# Altium schematic sheet CAN Bus Network.SchDoc
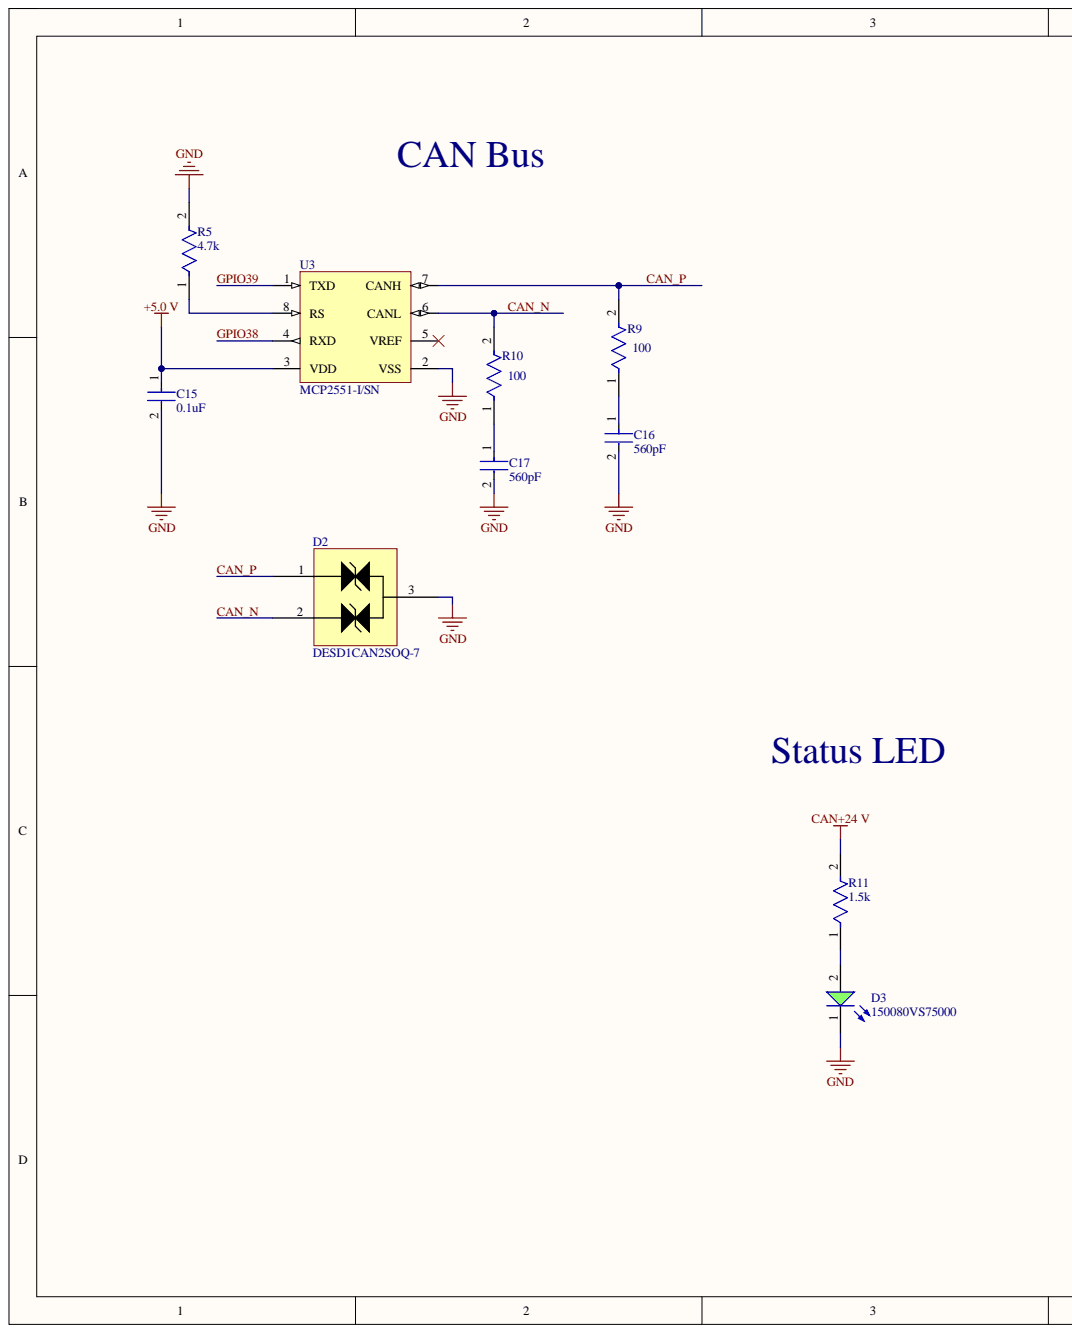
[diagram p0: CAN Bus Network.SchDoc, left]
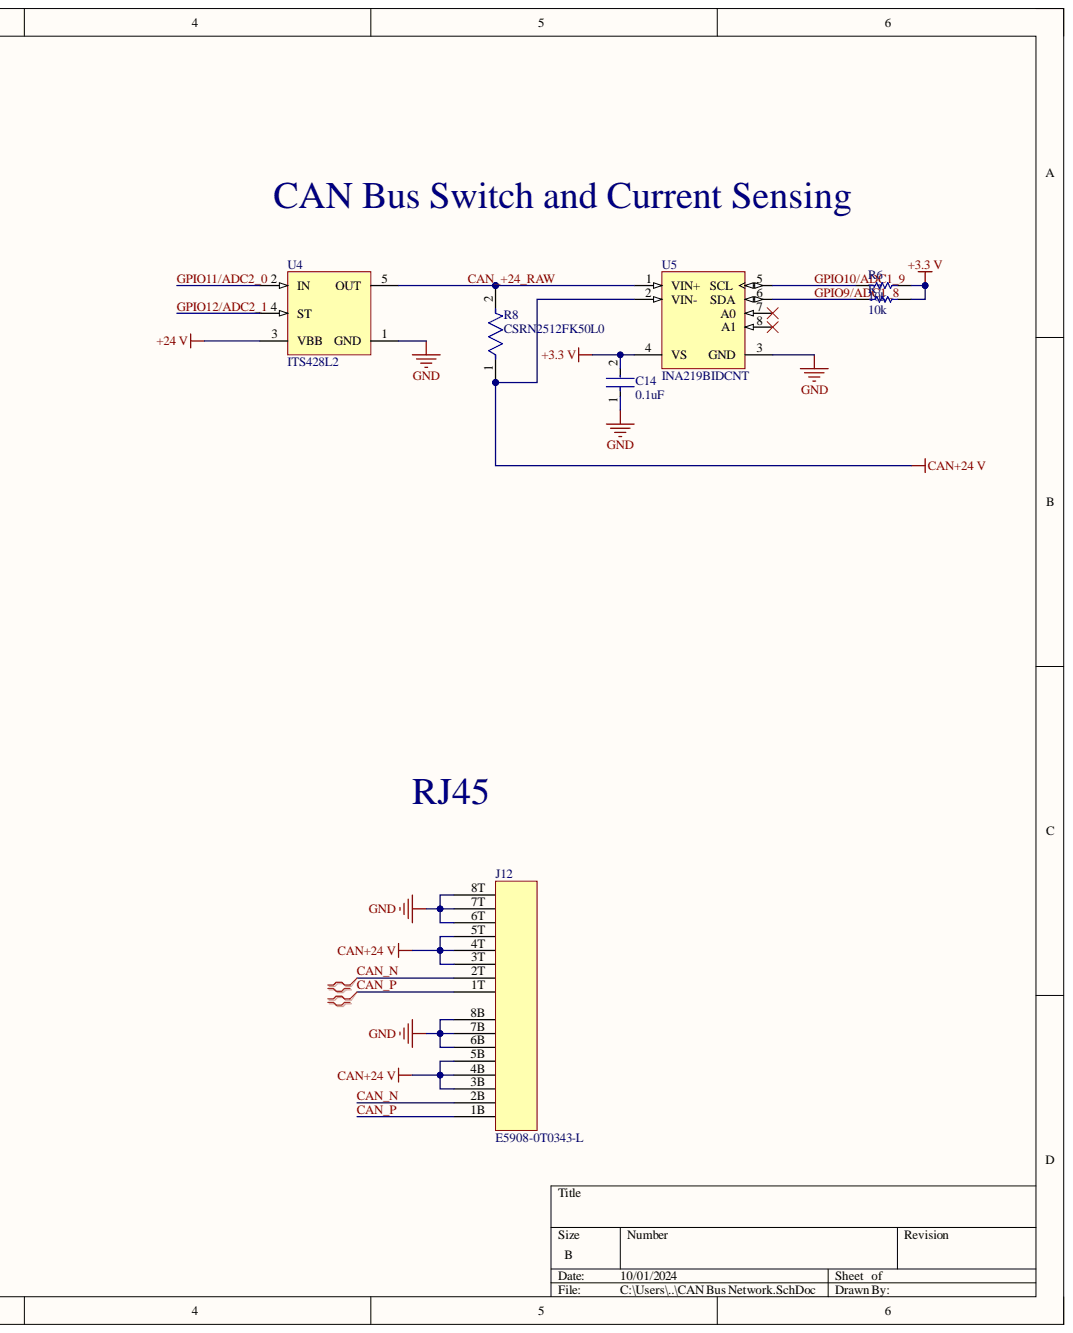
[diagram p1: CAN Bus Network.SchDoc, right]

## Parts
C14  0.1uF  [FP-CC0603-DA-IPC_A]
C15  0.1uF  [FP-CC0603-DA-IPC_A]
C16  560pF  [FP-CC1206-1_25-IPC_A]
C17  560pF  [FP-CC1206-1_25-IPC_A]
D2  DESD1CAN2SOQ-7  [SOT95P245X110-3N]
D3  150080VS75000  [WL-SMCW_0805_150080xx75000]
J12  E5908-0T0343-L  [E5908-0T0343-L-Footprint-1]
R5  4.7k  [FP-RT0805-IPC_A]
R6  10k  [RESC2013X60X35ML10T20]
R7  10k  [RESC2013X60X35ML10T20]
R8  CSRN2512FK50L0  [FP-CSRN2512-IPC_B]
R9  100  [FP-RT0805-0_6-IPC_A]
R10  100  [FP-RT0805-0_6-IPC_A]
R11  1.5k  [FP-RT0805-IPC_A]
U3  MCP2551-I/SN  [MCHP-SN8_V]
U4  ITS428L2  [INF-PG-TO252-5-11_M]
U5  INA219BIDCNT  [DCN0008A_M]

## Nets
+3.3 V: C14.2 R6.1 R7.1 U5.4(VS)
+5.0 V: C15.1 U3.3(VDD)
+24 V: U4.3(VBB)
CAN+24 V: J12.3B J12.3T J12.4B J12.4T J12.5B J12.5T R8.1 R11.2 U5.2(VIN-)
GND: C14.1 C15.2 C16.2 C17.2 D2.3 D3.1 J12.6B J12.6T J12.7B J12.7T J12.8B J12.8T R5.2 U3.2(VSS) U4.1(GND) U5.3(GND)
CAN_+24_RAW: R8.2 U4.5(OUT) U5.1(VIN+)
CAN_N: D2.2 J12.2B J12.2T R10.2 U3.6(CANL)
CAN_P: D2.1 J12.1B J12.1T R9.2 U3.7(CANH)
GPIO9/ADC1_8: R7.2 U5.6(SDA)
GPIO10/ADC1_9: R6.2 U5.5(SCL)
GPIO11/ADC2_0: U4.2(IN)
GPIO12/ADC2_1: U4.4(ST)
GPIO38: U3.4(RXD)
GPIO39: U3.1(TXD)
NetC16_1: C16.1 R9.1
NetC17_1: C17.1 R10.1
NetD3_2: D3.2 R11.1
NetR5_1: R5.1 U3.8(RS)

Not connected: U3 5(VREF); U5 7(A0) 8(A1)

## Library data of the parts
C14 C15 = CMP-00002-1: Ceramic Capacitor, Multilayer, Ceramic, 50V, 10% +Tol, 10% -Tol, X7R, 15% TC, 0.1uF, Surface Mount, 0603; Multilayer: Yes; Value: 100nF; Capacitor Type: Ceramic; Case/Package: 0603; Component Kind: Standard; Dielectric Material: Ceramic; Height: 0.8mm; JESD-609 Code: e3; Length: 1.6mm; Library Name: C0514760 C0514760; Max Operating Temperature: 125°C; Min Operating Temperature: -55°C; Mounting Technology: Surface Mount; Package Shape: Rectangular; Package Style: SMTMeter; Packaging: Tape and Reel; Pins: 2; Rated DC Voltage (URdc): 50V; Reach SVHC Compliant: Yes; RoHS Compliant: Yes; Temperature Characteristics Code: X7R; Terminal Finish: Nickel; Termination: Wraparound; Tolerance  (fill in as +-): 10%; Tolerance (fill in as +-): 10%; Width: 0.8mm
C16 C17 = CMP-00008-1: Ceramic Capacitor, Multilayer, Ceramic, 50V, 10% +Tol, 10% -Tol, X7R, 15% TC, 0.00056uF, Surface Mount, 1206; Multilayer: Yes; Capacitor Type: Ceramic; Case/Package: 1206; Component Kind: Standard; Dielectric Material: Ceramic; JESD-609 Code: e3; Library Name: C0514760 C0514760; Max Operating Temperature: 125°C; Min Operating Temperature: -55°C; Mounting Technology: Surface Mount; Package Shape: Rectangular; Packaging: Tape and Reel; Pins: 2; Rated DC Voltage (URdc): 50V; Reach SVHC Compliant: Yes; RoHS Compliant: Yes; Temperature Characteristics Code: X7R; Terminal Finish: Tin; Termination: Wraparound; Tolerance  (fill in as +-): 10%; Tolerance (fill in as +-): 10%; Value: 560pF
D2 = CMP-00024-2: Trans Voltage Suppressor Diode, 200W, 24V V(RWM), Bidirectional, 2 Element, Silicon; Additional Feature: HIGH RELIABILITY; Max Breakdown Voltage: 30.3V; Max Power Dissipation: 300mW; Min Breakdown Voltage: 25.4V; Number of Elements: 2; Package Body Material: Plastic; Reference Standard: AEC-Q101; Time @ Peak Reflow Temperature-Max (s): 30s; Component Kind: Standard; Diode Element Material: SILICON; Diode Type: TRANS VOLTAGE SUPPRESSOR; JESD-30 Code: R-PDSO-G3; JESD-609 Code: e3; Library Name: C0514760 C0514760; Max Operating Temperature: 150°C; Min Operating Temperature: -65°C; Moisture Sensitivity Level: 1; Non-rep Peak Rev Power Dis-Max: 200W; Package Shape: Rectangular; Package Style: SMALL OUTLINEMeter; Peak Reflow Temperature (Cel): 260°C; Pins: 3; Polarity: Bidirectional; Reach SVHC Compliant: Yes; Rep Pk Reverse Voltage-Max: 24V; RoHS Compliant: Yes; Surface Mount: Yes; Technology: AVALANCHE; Terminal Finish: Tin; Terminal Form: Gull Wing; Terminal Position: DUAL
D3 = CMP-00021-1: Single Color LED, Bright Green, Water Clear, 1.4mm; Channels: 1; Forward Current: 30mA; Luminous Intensity-Nom: 40mcd; Overall Height: 0.7mm; Shape: Rectangular; Viewing Angle: 140°; Color: Green; Component Kind: Standard; Lens Style: WATER CLEAR; Library Name: C0514760 C0514760; Max Operating Temperature: 85°C; Min Operating Temperature: -40°C; Mounting Technology: Surface Mount; Optoelectronic Device Type: SINGLE COLOR LED; Packaging: Tape and Reel; Pins: 2; Reach SVHC Compliant: Yes; RoHS Compliant: Yes; Size: 1.4mm; Terminal Pitch: 2.1mm
J12 = CMP-002-00077-7: Telecom and Datacom Connector, 16 Contact(s), Female, Right Angle, Solder Terminal, Jack; Manufacturer Series: E5908; DIN Conformance: No; Number of Contacts: 16; Option: General Purpose; Body/Shell Style: JACK; Component Kind: Standard; Connector Type: TELECOM AND DATACOM CONNECTOR; Contact Finish - Mating: GOLD (15) OVER NICKEL; Contact Finish - Termination: GOLD (15) OVER NICKEL; Contact Gender: Female; Empty Shell: No; Filter Feature: No; Flammability Rating: UL94 V-0; IEC Conformance: No; Insulation Material: Glass; JESD-609 Code: e4; Library Name: C0514760 C0514760; MIL Conformance: No; Mixed Contacts: No; Mounting Style: RIGHT ANGLE; Mounting Technology: Board; Reach SVHC Compliant: Yes; RoHS Compliant: Yes; Shell Material: Glass; Termination: Solder
R5 = CMP-00015-1: Fixed Resistor, Thin Film, 0.125W, 4700ohm, 150V, 1% +/-Tol, 50ppm/Cel, Surface Mount, 0805; Additional Feature: HIGH PRECISION; Package Height: 0.5mm; Package Length: 2mm; Package Width: 1.25mm; Power: 125mW; Rated Temperature: 70°C; Temperature Coefficient: 50ppm/°C; Value: 4.7kR; Case/Package: 0805; Component Kind: Standard; Construction: Chip; Library Name: C0514760 C0514760; Max Operating Temperature: 155°C; Min Operating Temperature: -55°C; Mounting Technology: Surface Mount; Package Style: SMTMeter; Packaging: Tape and Reel; Pins: 2; Reach SVHC Compliant: Yes; Resistor Type: FIXED RESISTOR; RoHS Compliant: Yes; Technology: THIN FILM; Termination: Wraparound; Tolerance: 1%; Working Voltage: 150V
R6 R7 = CMP-00012-1: Fixed Resistor, Metal Glaze/thick Film, 0.125W, 10000ohm, 150V, 1% +/-Tol, 100ppm/Cel, Surface Mount, 0805; Additional Feature: LASER TRIMMABLE; Package Height: 0.5mm; Package Length: 2mm; Package Width: 1.25mm; Power: 125mW; Rated Temperature: 70°C; Temperature Coefficient: 100ppm/°C; Value: 10kR; Case/Package: 0805; Component Kind: Standard; Construction: Chip; JESD-609 Code: e3; Library Name: C0514760 C0514760; Max Operating Temperature: 155°C; Min Operating Temperature: -55°C; Mounting Technology: Surface Mount; Package Shape: Rectangular; Package Style: SMTMeter; Packaging: Tape and Reel; Pins: 2; Reach SVHC Compliant: Yes; Resistor Type: FIXED RESISTOR; RoHS Compliant: Yes; Technology: METAL GLAZE/THICK FILM; Terminal Finish: Nickel; Termination: Wraparound; Tolerance: 1%; Working Voltage: 150V
R8 = CMP-00034-1: Fixed Resistor, Metal Glaze/thick Film, 2W, 0.05ohm, 1% +/-Tol, 200ppm/Cel, Surface Mount, 2512; Package Height: 0.6mm; Package Length: 6.35mm; Package Width: 3.15mm; Rated Temperature: 70°C; Temperature Coefficient: 200ppm/°C; Value: 50mR; Case/Package: 2512; Component Kind: Standard; Construction: Rectangular; JESD-609 Code: e3; Library Name: C0514760 C0514760; Max Operating Temperature: 155°C; Min Operating Temperature: -55°C; Mounting Technology: Surface Mount; Package Shape: Rectangular; Package Style: SMTMeter; Packaging: Tape and Reel; Pins: 2; Power: 2W; Reach SVHC Compliant: Yes; Resistor Type: FIXED RESISTOR; RoHS Compliant: Yes; Technology: METAL GLAZE/THICK FILM; Terminal Finish: Nickel; Termination: Wraparound; Tolerance: 1%
R9 R10 = CMP-00016-1: Fixed Resistor, Thin Film, 0.125W, 100ohm, 150V, 0.1% +/-Tol, 25ppm/Cel, Surface Mount, 0805; Additional Feature: HIGH PRECISION; Package Height: 0.5mm; Package Length: 2mm; Package Width: 1.25mm; Power: 125mW; Rated Temperature: 70°C; Temperature Coefficient: 25ppm/°C; Value: 100R; Case/Package: 0805; Component Kind: Standard; Construction: Chip; Library Name: C0514760 C0514760; Max Operating Temperature: 155°C; Min Operating Temperature: -55°C; Mounting Technology: Surface Mount; Package Style: SMTMeter; Packaging: Tape and Reel; Pins: 2; Reach SVHC Compliant: Yes; Resistor Type: FIXED RESISTOR; RoHS Compliant: Yes; Technology: THIN FILM; Termination: Wraparound; Tolerance: 0.1%; Working Voltage: 150V
R11 = CMP-00013-1: Fixed Resistor, Thin Film, 0.125W, 1500ohm, 150V, 1% +/-Tol, 50ppm/Cel, Surface Mount, 0805; Additional Feature: HIGH PRECISION; Package Height: 0.5mm; Package Length: 2mm; Package Width: 1.25mm; Power: 125mW; Rated Temperature: 70°C; Temperature Coefficient: 50ppm/°C; Value: 1.5kR; Case/Package: 0805; Component Kind: Standard; Construction: Chip; Library Name: C0514760 C0514760; Max Operating Temperature: 155°C; Min Operating Temperature: -55°C; Mounting Technology: Surface Mount; Package Style: SMTMeter; Packaging: Tape and Reel; Pins: 2; Reach SVHC Compliant: Yes; Resistor Type: FIXED RESISTOR; RoHS Compliant: Yes; Technology: THIN FILM; Termination: Wraparound; Tolerance: 1%; Working Voltage: 150V
U3 = CMP-015-00008-2: CAN Transceiver, 1-Trnsvr, PDSO8; Channels: 1; Data Rate: 1000Mbps; Max Supply Current: 75uA; Nominal Supply Voltage: 5V; Package Body Material: Plastic; Package Equivalence Code: SOP8,.25; Qualification Status: Not Qualified; Telecom IC Type: INTERFACE CIRCUIT; Time @ Peak Reflow Temperature-Max (s): 40s; Case/Package: SOP; Component Kind: Standard; Height - Seated (Max): 1.75mm; JESD-30 Code: R-PDSO-G8; JESD-609 Code: e3; Length: 4.9mm; Library Name: C0514760 C0514760; Max Operating Temperature: 85°C; Min Operating Temperature: -40°C; Moisture Sensitivity Level: 1; Number of Transceivers: 1; Package Shape: Rectangular; Package Style: SMALL OUTLINEMeter; Peak Reflow Temperature (Cel): 260°C; Pins: 8; Power Supplies: 5V; Reach SVHC Compliant: Yes; RoHS Compliant: Yes; Surface Mount: Yes; Technology: CMOS; Temperature Grade: INDUSTRIAL; Terminal Finish: Tin; Terminal Form: Gull Wing; Terminal Pitch: 1.27mm; Terminal Position: DUAL; Width: 3.9mm
U4 = CMP-00032-2: Buffer/Inverter Based Peripheral Driver, 1 Driver, 1.8A, PSSO4; Built-in Protections: Over Current,Over Voltage,Under Voltage,TRANSIENT,THERMAL; Channels: 1; Interface IC Type: BUFFER OR INVERTER BASED PERIPHERAL DRIVER; Max Supply Voltage: 43V; Min Supply Voltage: 4.75V; Nominal Supply Voltage: 5V; Output Current Flow Direction: SINK; Package Body Material: Plastic; Power Supplies: 12V; Qualification Status: Not Qualified; Turn-Off Delay Time: 200us; Case/Package: TO-252; Component Kind: Standard; Driver Number of Bits: 1; Height - Seated (Max): 2.5mm; Length: 6.5mm; Library Name: C0514760 C0514760; Max Operating Temperature: 85°C; Min Operating Temperature: -30°C; Moisture Sensitivity Level: 1; Output Peak Current Limit-Nom: 1.8A; Package Shape: Rectangular; Package Style: SMALL OUTLINEMeter; Pins: 4; Reach SVHC Compliant: No; RoHS Compliant: Yes; Surface Mount: Yes; Temperature Grade: COMMERCIAL EXTENDED; Terminal Form: Gull Wing; Terminal Pitch: 1.14mm; Terminal Position: SINGLE; Turn-On Delay Time: 200us; Width: 6.22mm
U5 = CMP-00029-1: Power Supply Support Circuit, Fixed, 2 Channel, 2V, PDSO8; Adjustable Threshold: No; Channels: 1; Max Supply Voltage: 5.5V; Min Supply Voltage: 3V; Nominal Supply Voltage: 3.3V; Number of Channels: 2; Package Body Material: Plastic; Package Equivalence Code: TSSOP8,.1; Qualification Status: Not Qualified; Supply Current-Max (Isup): 1mA; Case/Package: LSSOP; Component Kind: Standard; Height - Seated (Max): 1.45mm; JESD-30 Code: R-PDSO-G8; JESD-609 Code: e4; Length: 2.9mm; Library Name: C0514760 C0514760; Max Operating Temperature: 125°C; Min Operating Temperature: -40°C; Moisture Sensitivity Level: 2; Package Shape: Rectangular; Package Style: SMALL OUTLINE, LOW PROFILE, SHRINK PITCHMeter; Peak Reflow Temperature (Cel): 260°C; Pins: 8; Power Supplies: 3.3V; Reach SVHC Compliant: Yes; RoHS Compliant: Yes; Surface Mount: Yes; Temperature Grade: AUTOMOTIVE; Terminal Finish: Nickel; Terminal Form: Gull Wing; Terminal Pitch: 0.65mm; Terminal Position: DUAL; Throw Configuration: POWER SUPPLY SUPPORT CIRCUIT; Width: 1.6mm
